# Altium schematic sheet GPS.SchDoc
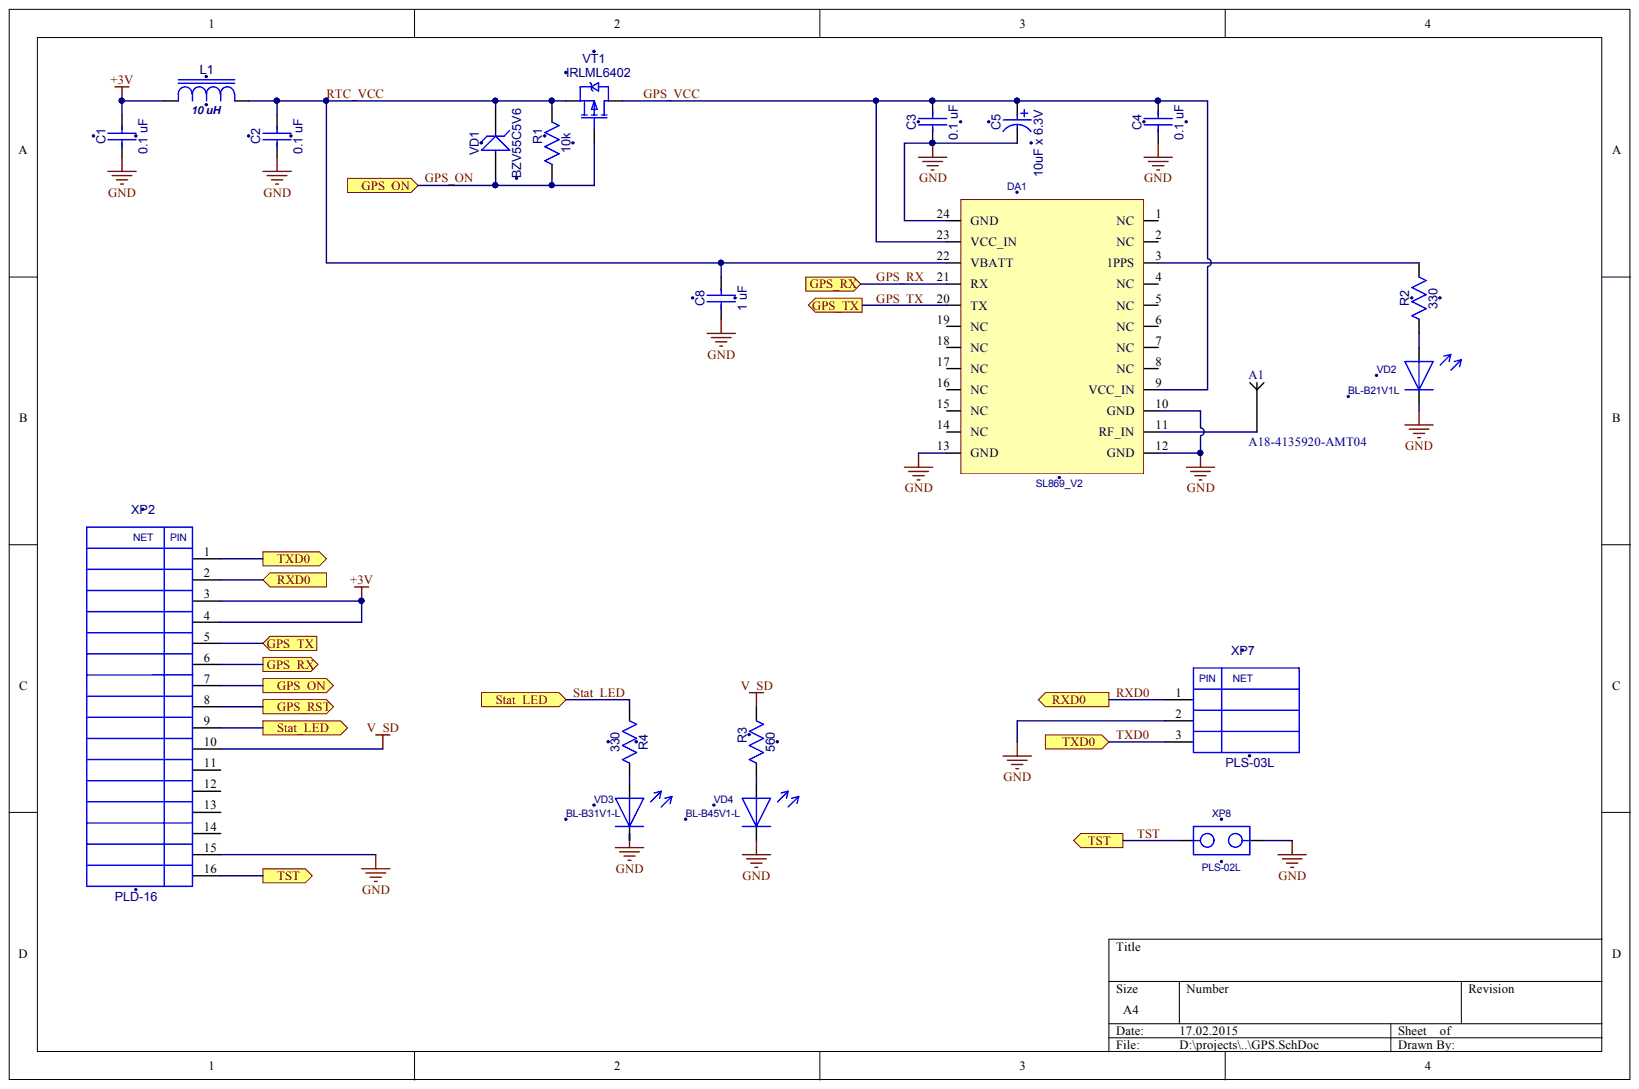
[diagram p0: GPS.SchDoc]

## Parts
A1  A18-4135920-AMT04  [BY-GPS-204]
C1  0.1 uF  [CC0603-3M]
C2  0.1 uF  [CC0603-3M]
C3  0.1 uF  [CC0603-3M]
C4  0.1 uF  [CC0603-3M]
C5  10uF x 6.3V  [TAJ-A_M]
C8  1 uF  [CC0603-3M]
DA1  SL869_V2  [SL868]
L1  10 uH  [RC0805-MIDI-2]
R1  10k  [RC0603-3M]
R2  330  [RC0603-3M]
R3  560  [RC0603-3M]
R4  330  [RC0603-3M]
VD1  BZV55C5V6  [SOD80]
VD2  BL-B21V1L  [LED3]
VD3  BL-B31V1-L  [LED3]
VD4  BL-B45V1-L  [LED3]
VT1  IRLML6402  [SOT-23(123)]
XP2  PLD-16  [PIN16]
XP7  PLS-03L  [PLS-03L]
XP8  PLS-02L  [PLS-02L]

## Ports
GPS_ON, GPS_RST, GPS_RX, GPS_TX, RXD0, STAT_LED, TST, TXD0

## Nets
+3V: C1.2 L1.1 XP2.3 XP2.4
GND: C1.1 C2.1 C3.2 C4.2 C5.2 C8.1 DA1.10(GND) DA1.12(GND) DA1.13(GND) DA1.24(GND) VD2.1 VD3.1 VD4.1 XP2.15 XP7.2 XP8.2
V_SD: R3.2 XP2.10
GPS_ON: R1.2 VD1.1 VT1.1 XP2.7 ; port GPS_ON
GPS_RST: XP2.8 ; port GPS_RST
GPS_RX: DA1.21(RX) XP2.6 ; port GPS_RX
GPS_TX: DA1.20(TX) XP2.5 ; port GPS_TX
GPS_VCC: C3.1 C4.1 C5.1 DA1.9(VCC_IN) DA1.23(VCC_IN) VT1.3
RTC_VCC: C2.2 C8.2 DA1.22(VBATT) L1.2 R1.1 VD1.2 VT1.2
RXD0: XP2.2 XP7.1 ; port RXD0
STAT_LED: R4.2 XP2.9 ; port STAT_LED
TST: XP2.16 XP8.1 ; port TST
TXD0: XP2.1 XP7.3 ; port TXD0
NetA1_1: A1.1 DA1.11(RF_IN)
NetDA1_3: DA1.3(1PPS) R2.1
NetR2_2: R2.2 VD2.2
NetR3_1: R3.1 VD4.2
NetR4_1: R4.1 VD3.2

Not connected: DA1 1(NC) 2(NC) 4(NC) 5(NC) 6(NC) 7(NC) 8(NC) 14(NC) 15(NC) 16(NC) 17(NC) 18(NC) 19(NC); XP2 11 12 13 14

## Library data of the parts
A1 = BY-GPS-204: Passive GPS Antenna; Component Kind: Standard; Library Name: gprs_modem_2.SchLib
C1-C4 C8 = CC0603: Component Kind: Standard; Description: CC0603
  C1-C4: Value: 0.1 uF
  C8: Value: 1 uF
C5 = TAJ-A: Component Kind: Standard; Description: TAJ-A; Value: 10uF x 6.3V; Library Name: gprs_modem.SchLib
L1 = L0805: Component Kind: Standard; Description: L0805; Value: 10 uH
R1-R4 = RC0603: Component Kind: Standard; Description: RC0603
  R1: Value: 10k
  R2 R4: Value: 330
  R3: Value: 560
VD1 = BZV55C5V1: Zener Voltage Regulator Diode; ComponentField6: Pin 2 is not connected and not drawn.; ComponentField7: Published 29-Sep-2000; OriginalName: BZX84C10LT1; Component Kind: Standard; Simulation: BZX84C10LT1; Value: BZV55C5V6; Library Name: gprs_modem.SchLib
VD2-VD4 = LED_3: Component Kind: Standard; Description: LED_3; Library Name: gprs_modem.SchLib
  VD2: Value: BL-B21V1L
  VD3: Value: BL-B31V1-L
  VD4: Value: BL-B45V1-L
VT1 = IRLML6402: HEXFET Power MOSFET; ComponentField7: Published 8-Jun-2000; OriginalName: IRLML5103; Value: {Value}; Component Kind: Standard; Library Name: gprs_modem.SchLib
DA1 = SL869_V2: Component Kind: Standard; Description: SL869_V2; Library Name: gprs_modem.SchLib
XP2 = PLD-16: Component Kind: Standard; Description: PLD-16; Library Name: gprs_modem.SchLib
XP7 = PLS-03L: Component Kind: Standard; Description: PLS-03L; Library Name: gprs_modem.SchLib
XP8 = PLS-02L: Component Kind: Standard; Description: PLS-02L; Library Name: gprs_modem.SchLib
